# Altium schematic sheet BMS.SchDoc
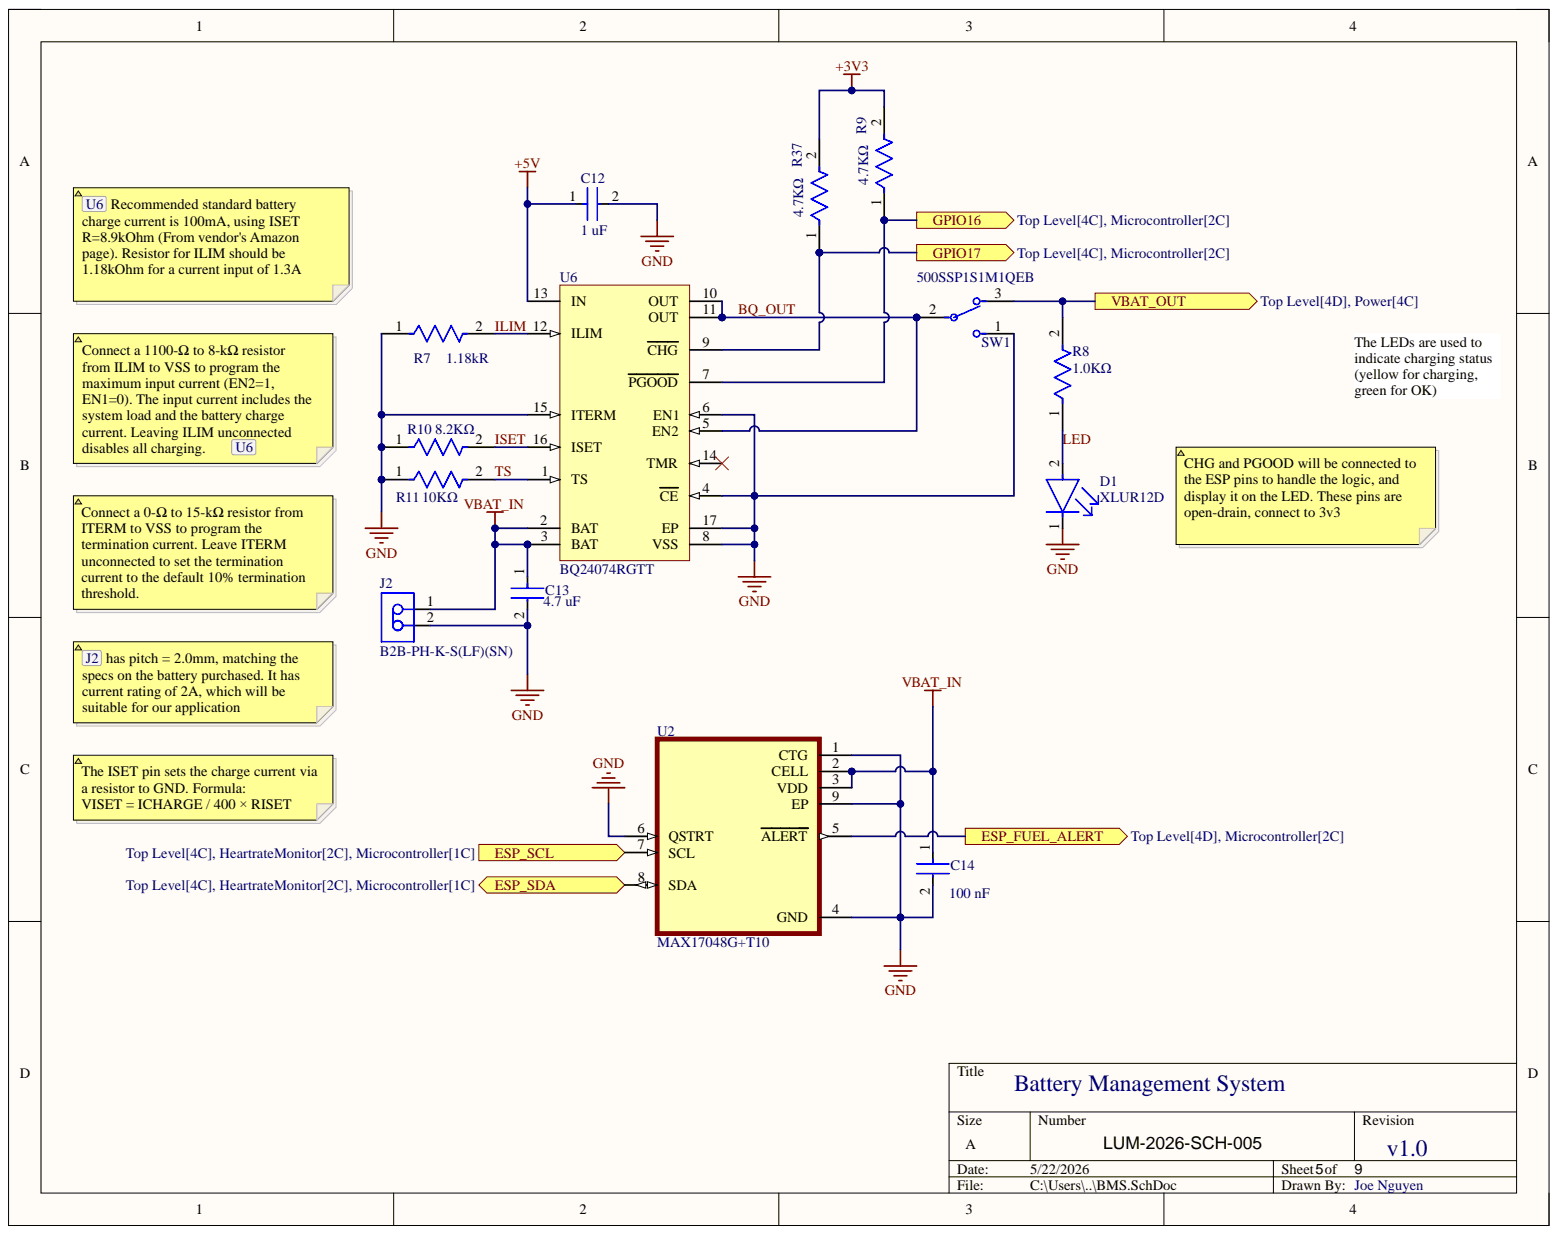
[diagram p0: BMS.SchDoc]

## Parts
C12  value 1 uF  Capacitance: 1 uF  [FP-CC0603-DA-MFG]  (hidden comment: CC0603KPX7R7BB105)
C13  value 4.7 uF  Capacitance: 4.7 uF  [FP-CC0603-DA-MFG]  (hidden comment: CC0603KRX5R7BB475)
C14  value 100 nF  Capacitance: 100 nF  [FP-CC0603-DA-MFG]  (hidden comment: CC0603KPX7R8BB104)
D1  XLUR12D  [FP-XLUR12D-MFG]
J2  B2B-PH-K-S(LF)(SN)  [JST-B2B-PH-K-S_V]
R7  Resistance: 1.18kR  [FP-AC0603-IPC_C]  (hidden comment: AC0603FR-071K18L)
R8  value 1.0KΩ  Resistance: 1.0KΩ  [FP-CPF0603-MFG]  (hidden comment: CPF0603F1K0C1)
R9  value 4.7KΩ  Resistance: 4.7KΩ  [FP-RT0603-MFG]  (hidden comment: RT0603BRE074K7L)
R10  value 8.2KΩ  Resistance: 8.2KΩ  [FP-CRGCQ0603-IPC_C]  (hidden comment: CRGCQ0603F8K2)
R11  value 10KΩ  Resistance: 10KΩ  [FP-CPF0603-MFG]  (hidden comment: CPF0603D10KE)
R37  value 4.7KΩ  Resistance: 4.7KΩ  [FP-RT0603-MFG]  (hidden comment: RT0603BRE074K7L)
SW1  500SSP1S1M1QEB  [FP-7101SD9CQE-MFG]
U2  MAX17048G+T10  [SON50P200X200X80-9N]
U6  BQ24074RGTT  [RGT0016C_V]

## Ports
ESP_FUEL_ALERT, ESP_SCL, ESP_SDA, GPIO16, GPIO17, VBAT_OUT

## Nets
+3V3: R9.2 R37.2
+5V: C12.1 U6.13(IN)
GND: C12.2 C13.2 C14.2 D1.1 J2.2 R7.1 R10.1 R11.1 SW1.1 U2.1(CTG) U2.4(GND) U2.6(QSTRT) U2.9(EP) U6.4(CE) U6.6(EN1) U6.8(VSS) U6.15(ITERM) U6.17(EP)
VBAT_IN: C13.1 C14.1 J2.1 U2.2(CELL) U2.3(VDD) U6.2(BAT) U6.3(BAT)
BQ_OUT: SW1.2 U6.5(EN2) U6.10(OUT) U6.11(OUT)
ESP_FUEL_ALERT: U2.5(ALERT) ; port ESP_FUEL_ALERT
ESP_SCL: U2.7(SCL) ; port ESP_SCL
ESP_SDA: U2.8(SDA) ; port ESP_SDA
GPIO16: R9.1 U6.7(PGOOD) ; port GPIO16
GPIO17: R37.1 U6.9(CHG) ; port GPIO17
ILIM: R7.2 U6.12(ILIM)
ISET: R10.2 U6.16(ISET)
LED: D1.2 R8.1
TS: R11.2 U6.1(TS)
VBAT_OUT: R8.2 SW1.3 ; port VBAT_OUT

Not connected: U6 14(TMR)

## Library data of the parts
C12 = CMP-03422-001047-1: CC0603 1 uF X7R 10.00% 16 V; Manufacturer: YAGEO; Material: Ceramic; Temperature Coefficient: 15%; Tolerance: 0.1; Voltage: 16 V; Automotive: No; Category: Cap; Component Kind: Standard; ComponentLink2Description: Datasheet; ComponentLink2URL: http://www.yageo.com/documents/recent/UPY-GPHC_X7R_6.3V-to-50V_18.pdf; Device Class L1: Passive Components; Device Class L2: Capacitors; Device Class L3: Ceramic Capacitors; Footprint Url: http://www.yageo.com/exep/pages/download/literatures/UPY-C_GEN_24.pdf; Height: 0.9mm; Lead Free: Yes; Library Name: Altium Content Vault; Manufacturer Part Number: CC0603KPX7R7BB105; Mouser Description: Cap Ceramic 1uF 16V X7R 10% SMD 0603 125°C Paper T/R; Octopart: https://octopart.com/cc0603kpx7r7bb105-yageo-25629533; Package: CC0603-DA; RoHS: TRUE; Temperature: -55°C to +125°C; Temperature Characteristic: X7R; Temperature Range High: +125°C; Temperature Range Low: -55°C; Voltage Rating: 16 V
C13 = CMP-03422-001236-1: CAP CER 4.7UF 16V X5R 0603; Manufacturer: YAGEO; Material: Ceramic; Temperature Coefficient: 15%; Tolerance: 0.1; Automotive: No; Category: Cap; Component Kind: Standard; ComponentLink2Description: Datasheet; ComponentLink2URL: http://www.yageo.com/documents/recent/UPY-GPHC_X7R_6.3V-to-50V_18.pdf; Device Class L1: Passive Components; Device Class L2: Capacitors; Device Class L3: Ceramic Capacitors; Digikey Description: CAP CER 4.7UF 16V X5R 0603; Footprint Url: http://www.yageo.com/exep/pages/download/literatures/UPY-C_GEN_24.pdf; Height: 0.9mm; Lead Free: Yes; Library Name: Altium Content Vault; Manufacturer Part Number: CC0603KRX5R7BB475; Mouser Description: Multilayer Ceramic Capacitors MLCC - SMD/SMT 4.7uF 16V X5R 10%; Octopart: https://octopart.com/cc0603krx5r7bb475-yageo-34978295; Package: CC0603-DA; RoHS: TRUE; Temperature: -55°C to +125°C; Temperature Characteristic: X5R; Temperature Range High: +125°C; Temperature Range Low: -55°C; Voltage: 16 V; Voltage Rating: 16 V
C14 = CMP-03422-000081-1: CC0603 100 nF X7R 10.00% 25 V; Manufacturer: YAGEO; Material: Ceramic; Temperature Coefficient: 15%; Tolerance: 0.1; Voltage: 25 V; Automotive: No; Category: Cap; Component Kind: Standard; ComponentLink2Description: Datasheet; ComponentLink2URL: http://www.yageo.com/documents/recent/UPY-GPHC_X7R_6.3V-to-50V_18.pdf; Device Class L1: Passive Components; Device Class L2: Capacitors; Device Class L3: Ceramic Capacitors; Footprint Url: http://www.yageo.com/exep/pages/download/literatures/UPY-C_GEN_24.pdf; Height: 0.9mm; Lead Free: Yes; Library Name: Altium Content Vault; Manufacturer Part Number: CC0603KPX7R8BB104; Mouser Description: Multilayer Ceramic Capacitors MLCC - SMD/SMT 100nF 25V X7R 10%; Octopart: https://octopart.com/cc0603kpx7r8bb104-yageo-12885111; Package: CC0603-DA; RoHS: TRUE; Temperature: -55°C to +125°C; Temperature Characteristic: X7R; Temperature Range High: +125°C; Temperature Range Low: -55°C; Voltage Rating: 25 V
D1 = CMP-02085-08376351-1: 627nm Red LED; 5x8.6mm, Through-Hole Mount with Red Diffused Round Lamp; Dominant Wavelength: 617nm; Forward Voltage: 1.9V; Luminous Intensity: 39mcd; Test Current: 10mA; Viewing Angle: 30°; Case/Package: Radial; Color: Red; Component Kind: Standard; Height: 8.6mm; Lens Color: Red; Lens Transparency: Diffused; Library Name: Altium Content Vault; Lifecycle Status: Production; Mount: Through Hole; RoHS: Compliant; Wavelength: 627nm
J2 = CMP-2000-05162-1: Male Header, Pitch 2 mm, 1 x 2 Position, Height 6 mm, Tail Length 3.4 mm, -25 to 85 degC, RoHS, Bulk; Manufacturer: JST; No of Positions: 2; Pitch: 2mm; Style/Orientation: StraightHeader/Plug; Component Kind: Standard; Datasheet URL: http://datasheet.octopart.com/B2B-PH-K-S%28LF%29%28SN%29-JST-datasheet-8324864.pdf; Datasheet Version: Nov-08; Library Name: Altium Content Vault; Manufacturer URL: http://www.jst.com/home2.html; Termination: Crimp; Type: ShroudedHeader
R7 = CMP-03412-035208-2: RES 1.18KΩ 1.00% 0.1W 0603; Max Power Dissipation: 100mW; Temperature Coefficient: 100ppm/°C; Tolerance: 1%; Voltage Rating: 50V; Case Code (Imperial): 0603; Case Code (Metric): 1608; Case/Package: 0603; Component Kind: Standard; Features: Moisture Resistant; Height: 0.022inch; Length: 0.063inch; Library Name: Altium Content Vault; Max Operating Temperature: 155°C; Min Operating Temperature: -55°C; Number of Terminations: 2; Packaging: Tape and Reel; Power Rating: 100mW; Resistor Type: Thick Film; RoHS Compliant: Yes; Series: AC; Termination: SMD/SMT; Width: 0.8mm
R8 = CMP-03211-002621-1: RES SMD 1.0KΩ 1% 1/16W 0603; Manufacturer: TE Connectivity; Power Rating: 0.063W; Temperature Coefficient: 50ppm/°C; Voltage Rating: 50V; Automotive: No; Category: Res; Component Kind: Standard; ComponentLink2Description: Datasheet; ComponentLink2URL: https://www.te.com/commerce/DocumentDelivery/DDEController?Action=showdoc&DocId=Data+Sheet%7F1773200%7FM%7Fpdf%7FEnglish%7FENG_DS_1773200_M.pdf%7F3-1676481-2; Composition: Thin Film; Device Class L1: Passive Components; Device Class L2: Resistors; Device Class L3: Chip SMD Resistors; Height: 0.55mm; Ipc Land Pattern Name: RESC15580X45; Lead Free: Yes; Library Name: Altium Content Vault; Manufacturer Part Number: CPF0603F1K0C1; Octopart: https://octopart.com/cpf0603f1k0c1-te+connectivity-42013134; octopart_url: https://octopart.com/cpf0603f1k0c1-te+connectivity-42013134; Package: CPF0603; packaging: 1k Reel; RoHS: TRUE; Series: CPF; Temperature: -55°C to +155°C; Temperature Range High: +155°C; Temperature Range Low: -55°C; Tolerance: 1%
R9 R37 = CMP-2000-04545-2: RES SMD 4.7K OHM 0.1% 1/10W 0603; Manufacturer: Yageo; Power Rating: 0.1W; Temperature Coefficient: 50 ppm/°C; Tolerance: 0.001; Voltage Rating: 75V; Automotive: No; Category: Res; Component Kind: Standard; ComponentLink2Description: Datasheet; ComponentLink2URL: http://www.yageo.com/documents/recent/PYu-RT_1-to-0.01_RoHS_L_9.pdf; Composition: Thin Film; Device Class L1: Passive Components; Device Class L2: Resistors; Device Class L3: Chip SMD Resistors; Digikey Description: RES SMD 4.7K OHM 0.1% 1/10W 0603; Footprint Url: http://www.yageo.com/exep/pages/download/literatures/PYu-R_Mount_10.pdf; Height: 0.55mm; Ipc Land Pattern Name: RESC16080X45; Lead Free: Yes; Library Name: Altium Content Vault; Manufacturer Part Number: RT0603BRE074K7L; Octopart: https://octopart.com/rt0603bre074k7l-yageo-1207135; Package: RT0603; RoHS: TRUE; Series: RT; Temperature: -55°C to +155°C; Temperature Range High: +155°C; Temperature Range Low: -55°C
R10 = CMP-03211-002200-1: CRGCQ0603 8.2KΩ 1% ±100ppm/°C 75V; Manufacturer: TE Connectivity; Power Rating: 0.1W; Tolerance: 0.01; Ambient Temperature Range High: +70°C; Category: Res; Component Kind: Standard; ComponentLink2Description: Datasheet; ComponentLink2URL: https://www.te.com/commerce/DocumentDelivery/DDEController?Action=showdoc&DocId=Data+Sheet%7F1773204-3%7F1%7Fpdf%7FEnglish%7FENG_DS_1773204-3_1.pdf%7F4-2176339-902; Composition: Thick Film; Device Class L1: Passive Components; Device Class L2: Resistors; Device Class L3: Chip SMD Resistors; Height: 0.55mm; Ipc Land Pattern Name: RESC16080X45; Lead Free: Yes; Library Name: Altium Content Vault; Manufacturer Part Number: CRGCQ0603F8K2; Mouser Description: Res Thick Film 0603 8.2K Ohm 1% 0.1W(1/10W) ±100ppm/C Pad SMD Automotive T/R; Octopart: https://octopart.com/crgcq0603f8k2-te+connectivity-91018094; Package: CRGCQ0603; RoHS: TRUE; Series: CRGCQ; Temperature: -55°C to +155°C; Temperature Coefficient: ±100ppm/°C; Temperature Range High: +155°C; Temperature Range Low: -55°C; Voltage Rating: 75V
R11 = CMP-03211-003506-1: RES SMD 10KΩ 0.5% 1/16W 0603; Manufacturer: TE Connectivity; Power Rating: 0.063W; Temperature Coefficient: 25ppm/°C; Voltage Rating: 50V; Automotive: No; Category: Res; Component Kind: Standard; ComponentLink2Description: Datasheet; ComponentLink2URL: https://www.te.com/commerce/DocumentDelivery/DDEController?Action=showdoc&DocId=Data+Sheet%7F1773200%7FM%7Fpdf%7FEnglish%7FENG_DS_1773200_M.pdf%7F3-1676481-2; Composition: Thin Film; Device Class L1: Passive Components; Device Class L2: Resistors; Device Class L3: Chip SMD Resistors; Height: 0.55mm; Ipc Land Pattern Name: RESC15580X45; Lead Free: Yes; Library Name: Altium Content Vault; Manufacturer Part Number: CPF0603D10KE; Octopart: https://octopart.com/cpf0603d10ke-te+connectivity-22737789; octopart_url: https://octopart.com/cpf0603d10ke-te+connectivity-22737789; Package: CPF0603; packaging: 5k Reel; RoHS: TRUE; Series: CPF; Temperature: -55°C to +155°C; Temperature Range High: +155°C; Temperature Range Low: -55°C; Tolerance: 0.5%
SW1 = CMP-01151-55410166-1: 7101SD9CQE Toggle Switch, Spdt, On-On, Maintained, 5A, 28Vdc, 3 Pcb Hole Cnt, Solder Terminal, Toggle; Actuator Length: 12.45mm; Actuator Material: Brass, Chrome; Contact Resistance: 10mΩ; Electrical Life: 100kcycles; Illumination Type: Illuminated, Non-Illuminated; Max Voltage Rating (AC): 250V; Max Voltage Rating (DC): 28V; Voltage Rating (AC): 120V; Component Kind: Standard; Contact Current Rating: 5A; Contact Material: Silver; Contact Plating: Silver; Current Rating: 5A; Depth: 6.86mm; Housing Material: Stainless Steel; Lead Free: Lead Free; Length: 12.7mm; Library Name: Altium Content Vault; Life (Cycles): 100kcycles; Max Current Rating: 5A; Max Operating Temperature: 85°C; Min Operating Temperature: -30°C; Mount: Through Hole; NexarPartId: 55410166; Orientation: Straight; Radiation Hardening: No; RoHS: Compliant; Switch Function: On-On; Termination: PC Pins; Throw Configuration: SPDT; Voltage Rating (DC): 28V
U2 = MAX17048G+T10: 3ÂµA 1-Cell/2-Cell Fuel Gauge with ModelGauge; MF: Analog Devices; Availability: In Stock; Check_prices: https://www.snapeda.com/parts/MAX17048G+T10/Analog+Devices/view-part/?ref=eda; Component Kind: Standard; Library Name: Student_Schlib_41070.SchLib; MP: MAX17048G+T10; Package: TDFN-8 Maxim; Price: None; Purchase-URL: https://pricing.snapeda.com/search/part/MAX17048G+T10/?ref=eda; SnapEDA_Link: https://www.snapeda.com/parts/MAX17048G+T10/Analog+Devices/view-part/?ref=snap; Supplier 1: DigiKey; Supplier Part Number 1: MAX17048G+T10CT-ND
U6 = CMP-0321-00218-2: USB-Friendly Li-Ion Battery Charger and Power-Path Management IC, 10.5 V OVP, 4.3 V, -40 to 85 degC, 16-pin QFN (RGT), Green (RoHS & no Sb/Br); Manufacturer: Texas Instruments; Lead Acid: N; SubFamily: Battery Charge Management; Vin(Min)(V): 4.3; Code_JEDEC: MO-220; Component Kind: Standard; ComponentLink1Description: Datasheet; ComponentLink1URL: http://www.ti.com/general/docs/lit/getliterature.tsp?genericPartNumber=BQ24074&fileType=pdf; DatasheetVersion: SLUS810G; Eco-Plan: Green (RoHS & no Sb/Br); Li-Ion: N; Li-Polymer: N; Library Name: Altium Content Vault; Mounting Technology: Surface Mount; NiCd: N; NiMH: N; Over Voltage Protection: N; PackageDescription: 16-Pin Plastic Quad Flat No-Lead, 3 x 3 mm Body, 0.5 mm Pitch, 1.7 x 1.7 mm Exposed Pad; PackageReference: RGT0016C; PackageVersion: revH, Oct-2011; PartNumber: BQ24074RGTT
